# Altium schematic sheet Remote Feedback.SchDoc("Remote Feedback")
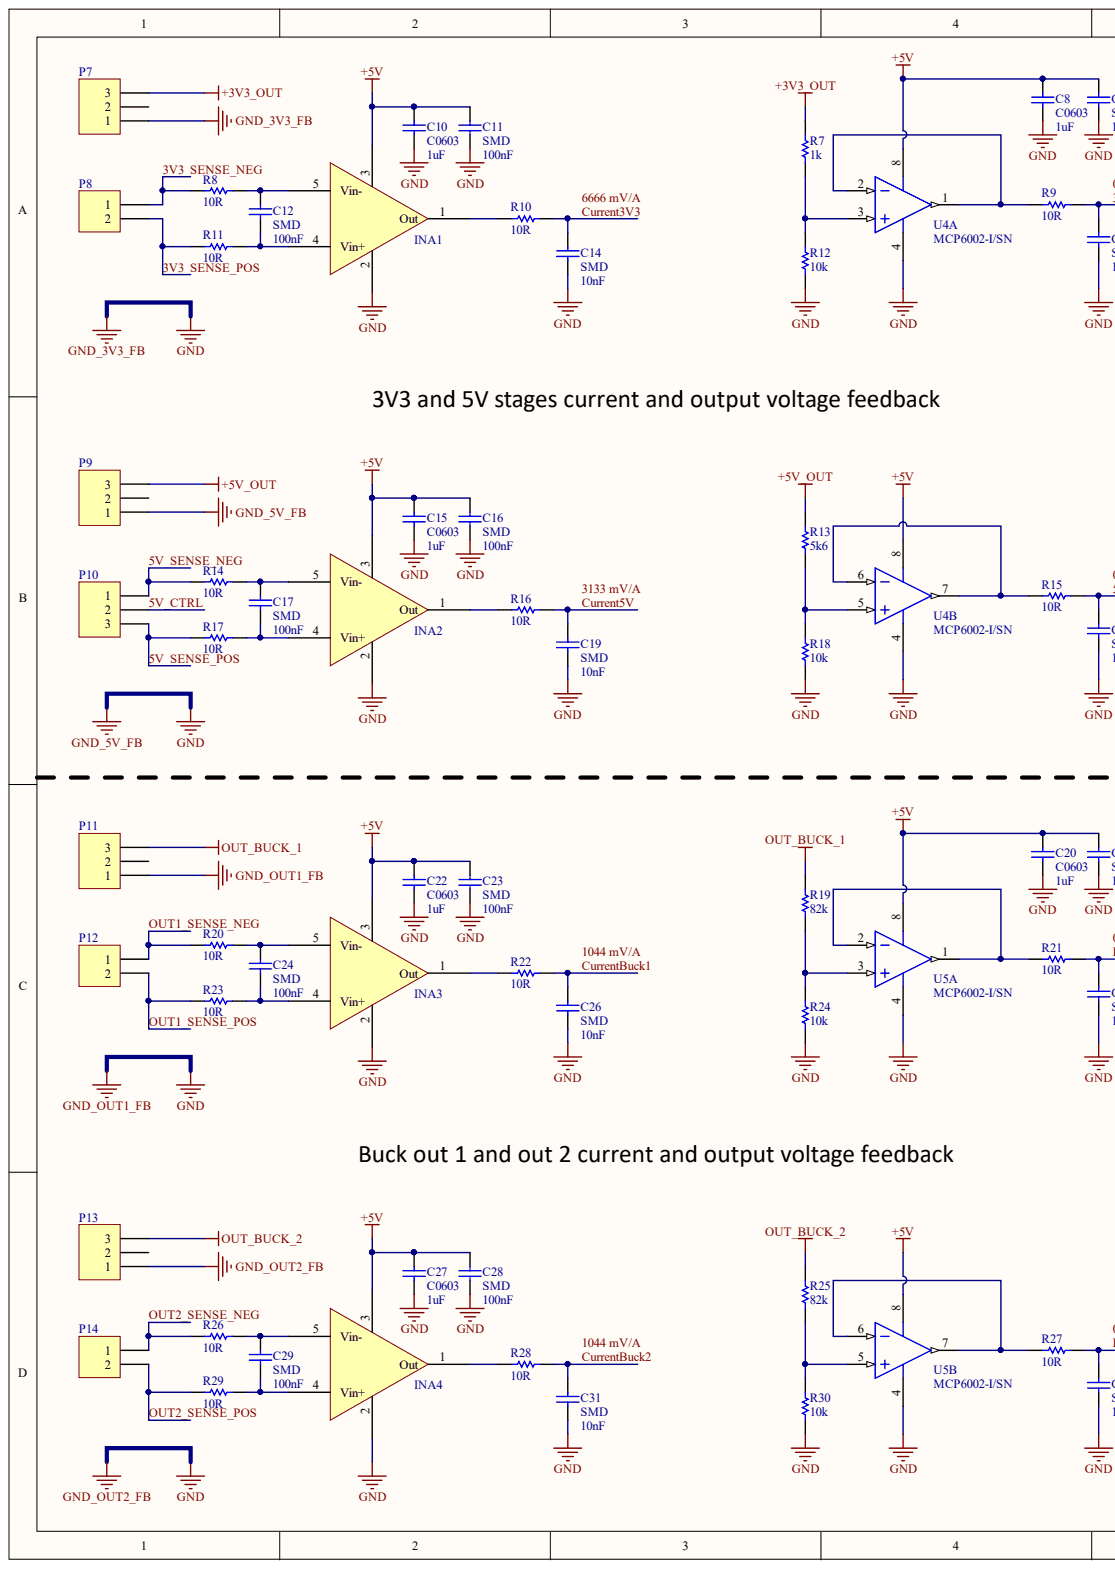
[diagram p0: Remote Feedback.SchDoc, left]
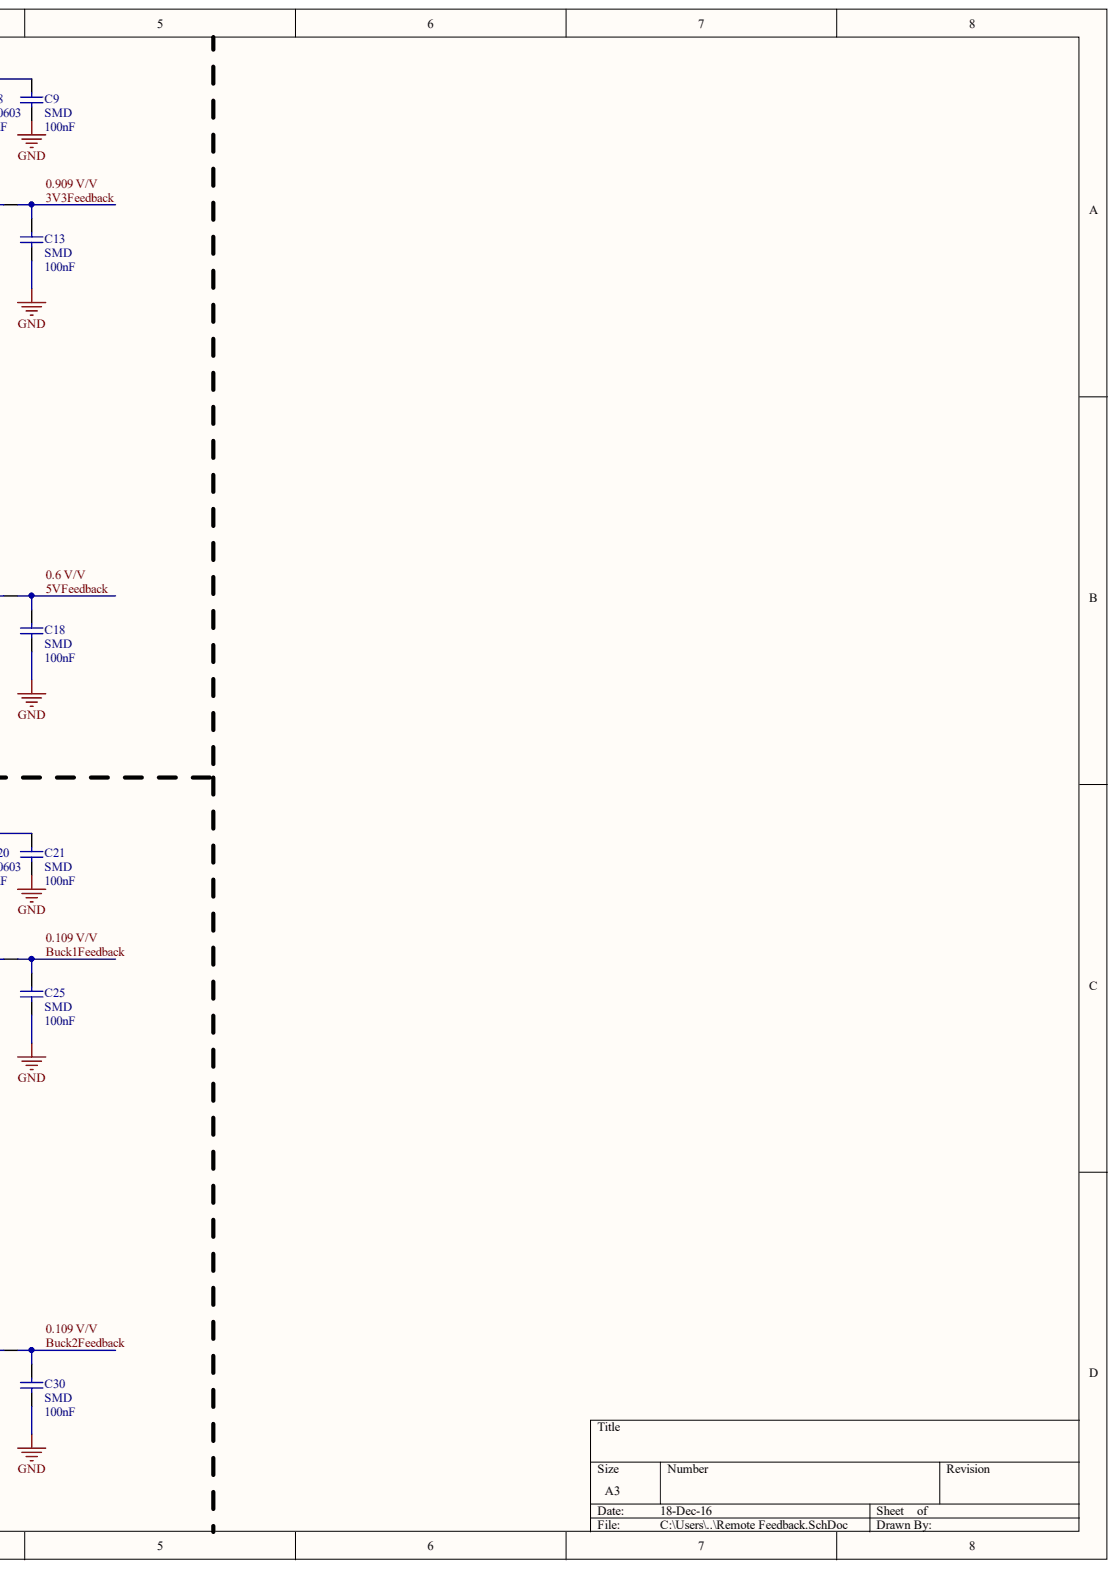
[diagram p1: Remote Feedback.SchDoc, right]

## Parts
C8  C0603  value 1uF  [0603]
C9  SMD  value 100nF  [1206]
C10  C0603  value 1uF  [0603]
C11  SMD  value 100nF  [1206]
C12  SMD  value 100nF  [1206]
C13  SMD  value 100nF  [1206]
C14  SMD  value 10nF  [1206]
C15  C0603  value 1uF  [0603]
C16  SMD  value 100nF  [1206]
C17  SMD  value 100nF  [1206]
C18  SMD  value 100nF  [1206]
C19  SMD  value 10nF  [1206]
C20  C0603  value 1uF  [0603]
C21  SMD  value 100nF  [1206]
C22  C0603  value 1uF  [0603]
C23  SMD  value 100nF  [1206]
C24  SMD  value 100nF  [1206]
C25  SMD  value 100nF  [1206]
C26  SMD  value 10nF  [1206]
C27  C0603  value 1uF  [0603]
C28  SMD  value 100nF  [1206]
C29  SMD  value 100nF  [1206]
C30  SMD  value 100nF  [1206]
C31  SMD  value 10nF  [1206]
INA1  INA196  [SOT-23-5]  (value not drawn)
INA2  INA196  [SOT-23-5]  (value not drawn)
INA3  INA196  [SOT-23-5]  (value not drawn)
INA4  INA196  [SOT-23-5]  (value not drawn)
P7  Header 3  [My_1X03]  (value not drawn)
P8  Header 2  [HDR1X2]  (value not drawn)
P9  Header 3  [My_1X03]  (value not drawn)
P10  Header 3  [My_1X03]  (value not drawn)
P11  Header 3  [My_1X03]  (value not drawn)
P12  Header 2  [HDR1X2]  (value not drawn)
P13  Header 3  [My_1X03]  (value not drawn)
P14  Header 2  [HDR1X2]  (value not drawn)
R7  value 1k  [8RB-1206]  (hidden comment: SMD)
R8  value 10R  [8RB-1206]  (hidden comment: SMD 1206)
R9  value 10R  [8RB-1206]  (hidden comment: SMD 1206)
R10  value 10R  [8RB-1206]  (hidden comment: SMD 1206)
R11  value 10R  [8RB-1206]  (hidden comment: SMD 1206)
R12  value 10k  [8RB-1206]  (hidden comment: SMD)
R13  value 5k6  [8RB-1206]  (hidden comment: SMD)
R14  value 10R  [8RB-1206]  (hidden comment: SMD 1206)
R15  value 10R  [8RB-1206]  (hidden comment: SMD 1206)
R16  value 10R  [8RB-1206]  (hidden comment: SMD 1206)
R17  value 10R  [8RB-1206]  (hidden comment: SMD 1206)
R18  value 10k  [8RB-1206]  (hidden comment: SMD)
R19  value 82k  [8RB-1206]  (hidden comment: SMD)
R20  value 10R  [8RB-1206]  (hidden comment: SMD 1206)
R21  value 10R  [8RB-1206]  (hidden comment: SMD 1206)
R22  value 10R  [8RB-1206]  (hidden comment: SMD 1206)
R23  value 10R  [8RB-1206]  (hidden comment: SMD 1206)
R24  value 10k  [8RB-1206]  (hidden comment: SMD)
R25  value 82k  [8RB-1206]  (hidden comment: SMD)
R26  value 10R  [8RB-1206]  (hidden comment: SMD 1206)
R27  value 10R  [8RB-1206]  (hidden comment: SMD 1206)
R28  value 10R  [8RB-1206]  (hidden comment: SMD 1206)
R29  value 10R  [8RB-1206]  (hidden comment: SMD 1206)
R30  value 10k  [8RB-1206]  (hidden comment: SMD)
U4  MCP6002-I/SN  [SOIC-SN8_N]  (parts A,B)
U5  MCP6002-I/SN  [SOIC-SN8_N]  (parts A,B)

## Nets
+3V3_OUT: P7.3 R7.2
+5V: C8.2 C9.2 C10.2 C11.2 C15.2 C16.2 C20.2 C21.2 C22.2 C23.2 C27.2 C28.2 INA1.3 INA2.3 INA3.3 INA4.3 U4.8 U5.8
+5V_OUT: P9.3 R13.2
GND: C8.1 C9.1 C10.1 C11.1 C13.1 C14.1 C15.1 C16.1 C18.1 C19.1 C20.1 C21.1 C22.1 C23.1 C25.1 C26.1 C27.1 C28.1 C30.1 C31.1 INA1.2 INA2.2 INA3.2 INA4.2 P7.1 P9.1 P11.1 P13.1 R12.1 R18.1 R24.1 R30.1 U4.4 U5.4
OUT_BUCK_1: P11.3 R19.2
OUT_BUCK_2: P13.3 R25.2
3V3_SENSE_NEG: P8.1 R8.1
3V3_SENSE_POS: P8.2 R11.1
3V3FEEDBACK: C13.2 R9.2
5V_CTRL: P10.2
5V_SENSE_NEG: P10.1 R14.1
5V_SENSE_POS: P10.3 R17.1
5VFEEDBACK: C18.2 R15.2
BUCK1FEEDBACK: C25.2 R21.2
BUCK2FEEDBACK: C30.2 R27.2
CURRENT3V3: C14.2 R10.2
CURRENT5V: C19.2 R16.2
CURRENTBUCK1: C26.2 R22.2
CURRENTBUCK2: C31.2 R28.2
OUT1_SENSE_NEG: P12.1 R20.1
OUT1_SENSE_POS: P12.2 R23.1
OUT2_SENSE_NEG: P14.1 R26.1
OUT2_SENSE_POS: P14.2 R29.1
NetC12_1: C12.1 INA1.4(Vin+) R11.2
NetC12_2: C12.2 INA1.5(Vin-) R8.2
NetC17_1: C17.1 INA2.4(Vin+) R17.2
NetC17_2: C17.2 INA2.5(Vin-) R14.2
NetC24_1: C24.1 INA3.4(Vin+) R23.2
NetC24_2: C24.2 INA3.5(Vin-) R20.2
NetC29_1: C29.1 INA4.4(Vin+) R29.2
NetC29_2: C29.2 INA4.5(Vin-) R26.2
NetINA1_1: INA1.1(Out) R10.1
NetINA2_1: INA2.1(Out) R16.1
NetINA3_1: INA3.1(Out) R22.1
NetINA4_1: INA4.1(Out) R28.1
NetR7_1: R7.1 R12.2 U4.3
NetR9_1: R9.1 U4.1 U4.2
NetR13_1: R13.1 R18.2 U4.5
NetR15_1: R15.1 U4.6 U4.7
NetR19_1: R19.1 R24.2 U5.3
NetR21_1: R21.1 U5.1 U5.2
NetR25_1: R25.1 R30.2 U5.5
NetR27_1: R27.1 U5.6 U5.7
0.6 V/V:
0.109 V/V:
0.909 V/V:
1044 MV/A:
3133 MV/A:
6666 MV/A:

Not connected: P7 2; P9 2; P11 2; P13 2

## Library data of the parts
C8 C10 C15 C20 C22 C27 = C0603: C1608X7R1C105K X7R; Note: Footprint corresponds to dimensions for bulk cassette packaging. This case size has tightened tolerances.; Code_IPC: 1608 [0603]; Code_JEITA: 0603; Component Kind: Standard; ComponentLink1Description: Manufacturer Link; ComponentLink1URL: http://www.kemet.com/; ComponentLink2Description: Datasheet; ComponentLink2URL: http://www.kemet.com/kemet/web/homepage/kechome.nsf/vapubfilesname/F3102TA.pdf/$file/F3102TA.pdf; DatasheetDocument: Mar-2001; LatestRevisionDate: 19-Mar-2004; LatestRevisionNote: Silkscreen size reduced.; Library Name: KEMET Chip Capacitor.IntLib; PackageDocument: Mar-2001; PackageReference: 0603; Published: 17-Jul-2002; Signal Integrity: C0603; Simulation: CAP; Publisher: Altium Limited
C9 C11-C14 C16-C19 C21 C23-C26 C28-C31 = C1206: Ceramic Chip Capacitor - Standard; Code_IPC: 3216 [1206]; Code_JEITA: 1206; Component Kind: Standard; ComponentLink1Description: Manufacturer Link; ComponentLink1URL: http://www.kemet.com/; ComponentLink2Description: Datasheet; ComponentLink2URL: http://www.kemet.com/kemet/web/homepage/kechome.nsf/vapubfilesname/F3102TA.pdf/$file/F3102TA.pdf; DatasheetDocument: Mar-2001; LatestRevisionDate: 19-Mar-2004; LatestRevisionNote: Silkscreen size reduced.; Library Name: KEMET Chip Capacitor.IntLib; PackageDocument: Mar-2001; PackageReference: 1206; Published: 17-Jul-2002; Signal Integrity: C1206; Simulation: CAP; Publisher: Altium Limited
INA1-INA4 = INA196: Current Shunt Monitor −16 V to +80 V Common-Mode Range; Component Kind: Standard; Library Name: NXT - IC.IntLib
P7 P9-P11 P13 = Header 3: Header, 3-Pin; Component Kind: Standard; LatestRevisionDate: 17-Jul-2002; LatestRevisionNote: Re-released for DXP Platform.; Library Name: Mylib.IntLib; Signal Integrity: Connector; Publisher: Altium Limited
P8 P12 P14 = Header 2: Header, 2-Pin; Component Kind: Standard; LatestRevisionDate: 17-Jul-2002; LatestRevisionNote: Re-released for DXP Platform.; Library Name: Miscellaneous Connectors.IntLib; Signal Integrity: Connector; Publisher: Altium Limited
R7-R30 = ERJ8BQ: Low Ohmic Value Thick Film Chip Resistor, 0.22 Ohm to 9.1 Ohm Range, 1%, 2% and 5% Tolerance, 1206 Size, 0.33 W; Code_IPC: 3216 [1206]; Component Kind: Standard; ComponentLink1Description: Manufacturer Link; ComponentLink1URL: http://www.panasonic.com/industrial/components/resistive_products.htm; ComponentLink2Description: Datasheet; ComponentLink2URL: http://www.panasonic.com/industrial/components/pdf/AOA0000CE3.pdf; LatestRevisionDate: 19-Mar-2004; LatestRevisionNote: Silkscreen size reduced.; Library Name: Panasonic Resistor.IntLib; PackageDocument: Mar-2003; PackageReference: 8RB-1206; Published: 17-Dec-2003; Signal Integrity: Res; Simulation: RESISTOR; Publisher: Altium Limited
U4 U5 = MCP6002-I/SN: 1 MHz, Low-Power Operational Amplifier, 8-Pin SOIC, Industrial Temperature; Code_IPC: SOIC127P600-8; Code_JEDEC: MS-012; Component Kind: Standard; ComponentLink1Description: Datasheet; ComponentLink1URL: http://ww1.microchip.com/downloads/en/DeviceDoc/21733j.pdf; ComponentLink2Description: Packaging Specification; ComponentLink2URL: http://www.microchip.com/stellent/groups/techpub_sg/documents/packagingspec/en012702.pdf; ComponentLink3Description: Microchip.com Home; ComponentLink3URL: http://www.microchip.com/; DatasheetVersion: revJ, Nov-2009; LatestRevisionDate: 02-Dec-2009; LatestRevisionNote: Updated to datasheet revision J, Nov-2009; Library Name: Microchip Linear Devices.IntLib; PackageDescription: 8-Lead Plastic Small Outline (SN) - Narrow, 3.90mm Body [SOIC]; PackageReference: SOIC-SN8; PackageVersion: revBB, Aug-2009; Published: 29-Jan-2009; Simulation: MCP6001; Supplier 1: Digi-Key; Supplier 2: Farnell; Supplier Part Number 1: MCP6002-I/SN-ND; Supplier Part Number 2: 1292246; Publisher: Altium Limited
